# Altium schematic sheet Faja Hub.SchDoc
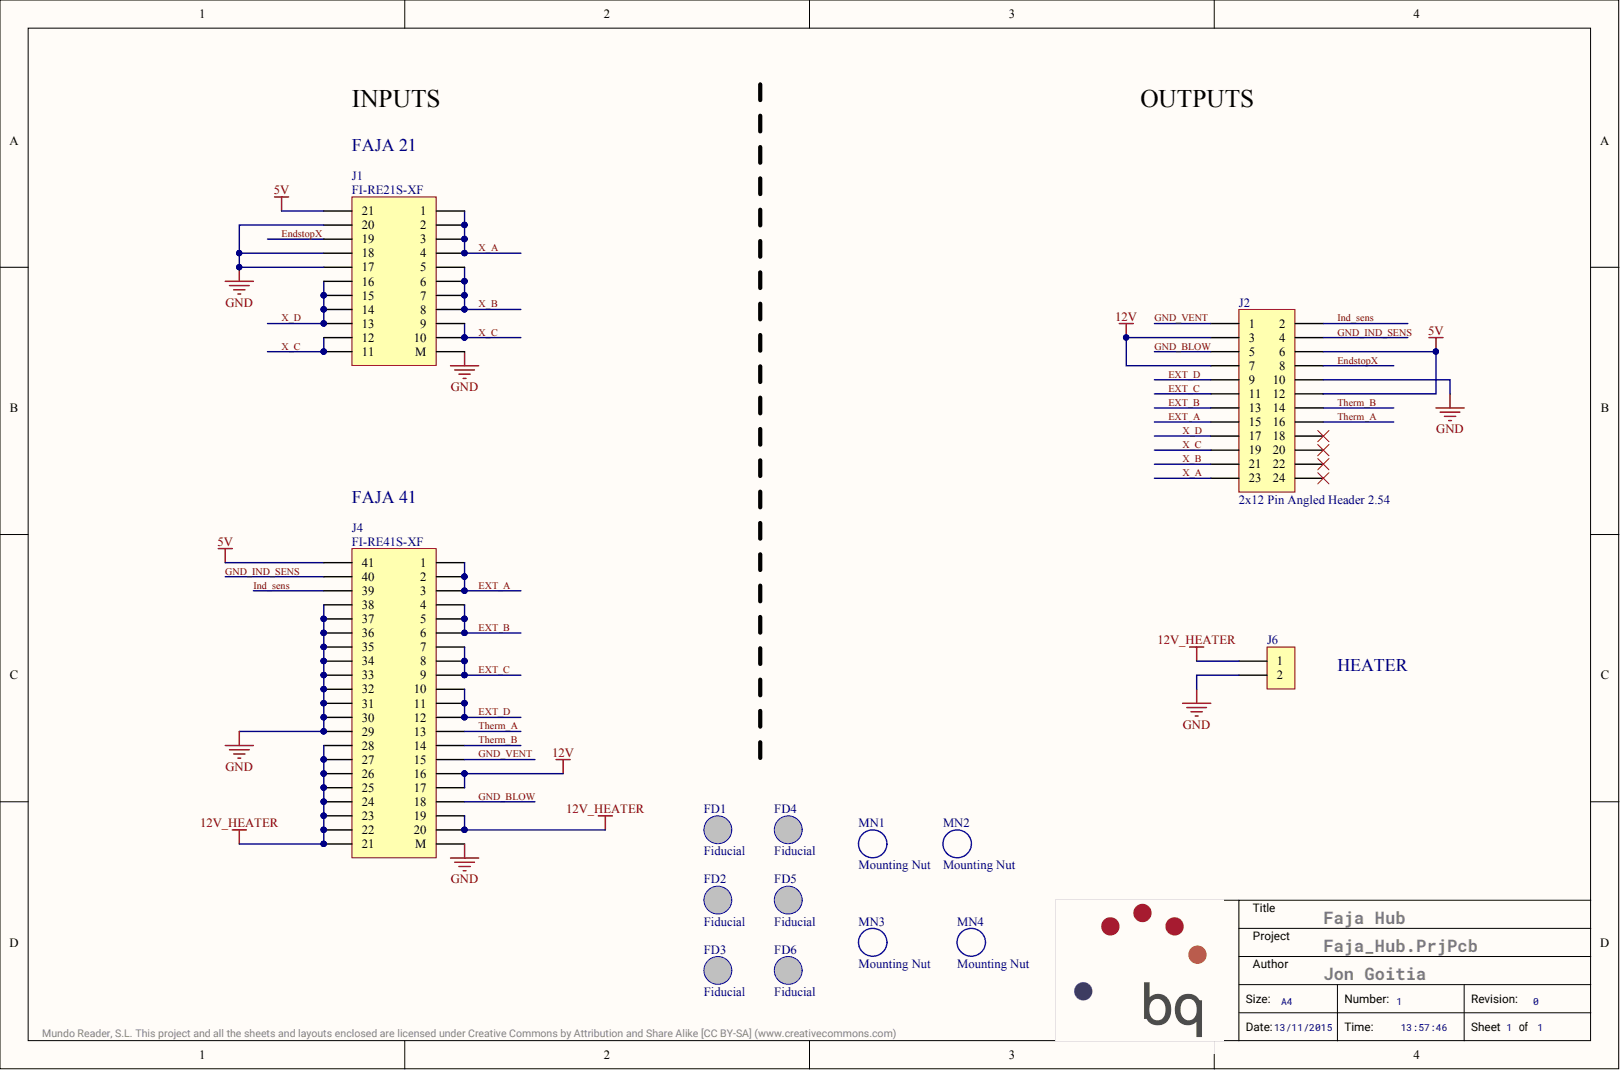
[diagram p0: Faja Hub.SchDoc]

## Parts
FD1  Fiducial  [Fiducial_1mm]  (no BOM)
FD2  Fiducial  [Fiducial_1mm]  (no BOM)
FD3  Fiducial  [Fiducial_1mm]  (no BOM)
FD4  Fiducial  [Fiducial_1mm]  (no BOM)
FD5  Fiducial  [Fiducial_1mm]  (no BOM)
FD6  Fiducial  [Fiducial_1mm]  (no BOM)
J1  FI-RE21S-XF  [FI-RE21S-VF]
J2  2x12 Pin Angled Header 2.54  value 24  [2x12 Pin Angled Header 2.54]
J4  FI-RE41S-XF  [FI-RE41S-HF]
J6  1x2 JST  [SM02B-SFHRS-TF]  (value not drawn)
MN1  Mounting Nut  [SMTSO-M4-4ET]
MN2  Mounting Nut  [SMTSO-M4-4ET]
MN3  Mounting Nut  [SMTSO-M4-4ET]
MN4  Mounting Nut  [SMTSO-M4-4ET]

## Nets
5V: J1.21 J2.6 J2.12 J4.41
12V: J2.3 J2.7 J4.16 J4.17
12V_HEATER: J4.19 J4.20 J4.21 J4.22 J4.23 J4.24 J4.25 J4.26 J4.27 J4.28 J6.1
GND: J1.17 J1.18 J1.20 J1.M J2.10 J4.29 J4.30 J4.31 J4.32 J4.33 J4.34 J4.35 J4.36 J4.37 J4.38 J4.M J6.2
ENDSTOPX: J1.19 J2.8
EXT_A: J2.15 J4.1 J4.2 J4.3
EXT_B: J2.13 J4.4 J4.5 J4.6
EXT_C: J2.11 J4.7 J4.8 J4.9
EXT_D: J2.9 J4.10 J4.11 J4.12
GND_BLOW: J2.5 J4.18
GND_IND_SENS: J2.4 J4.40
GND_VENT: J2.1 J4.15
IND_SENS: J2.2 J4.39
THERM_A: J2.16 J4.13
THERM_B: J2.14 J4.14
X_A: J1.1 J1.2 J1.3 J1.4 J2.23
X_B: J1.5 J1.6 J1.7 J1.8 J2.21
X_C: J1.9 J1.10 J1.11 J1.12 J2.19
X_D: J1.13 J1.14 J1.15 J1.16 J2.17

Not connected: J2 18 20 22 24

## Library data of the parts
FD1-FD6 = Fiducial 1mm: Fiducial Mark; Database Table Name: Fiducial$; Part Number: Fiducial 1mm; Library Name: Miscelanea.SVNDbLib
J1 = FI-RE21S-VF: Manufacturer: JAE; Database Table Name: Flex$; Component Kind: Standard; Library Name: Connectors_Flex.SVNDbLib; OrderingCode: FI-RE21S-VF; Part Number: FI-RE21S-VF
J2 = CON_DPH_THTA2.54_24: Connector, 24 pin Dual Angled Header 2.54mm; Manufacturer: Samtec; Database Table Name: Con_Dual_Angled_Header_THT_2#54$; OrderingCode: TSW-112-08-T-D-RA; Temp_ Max: 105; Temp_ Min: -55; Component Kind: Standard; Library Name: Conectores_PinHeader_2.54.SVNDbLib; Part Number: CON_DPH_THTA2.54_24
J4 = FI-RE41S-HF: Manufacturer: JAE; Database Table Name: Flex$; Component Kind: Standard; Library Name: Connectors_Flex.SVNDbLib; OrderingCode: FI-RE41S-HF; Part Number: FI-RE41S-HF
J6 = SM02B-SFHRS-TF: Connector, 2 pin JST; Current: 4A (AWG#22); Database Table Name: Power$; Temp_ Max: 85; Temp_ Min: -25; Component Kind: Standard; Library Name: Connectors_JST.SVNDbLib; Manufacturer: JST; OrderingCode: SM02B-SFHRS-TF; Part Number: SM02B-SFHRS-TF
MN1-MN4 = SMTSO-M4-4ET: SMTSO Mounting Nut; Manufacturer: PEM; Database Table Name: Mechanic$; Component Kind: Standard; Part Number: SMTSO-M4-4ET; Library Name: Miscelanea.SVNDbLib
